# Altium schematic sheet Régulateur USB HT BT.SchDoc("Régulateur USB HT BT")
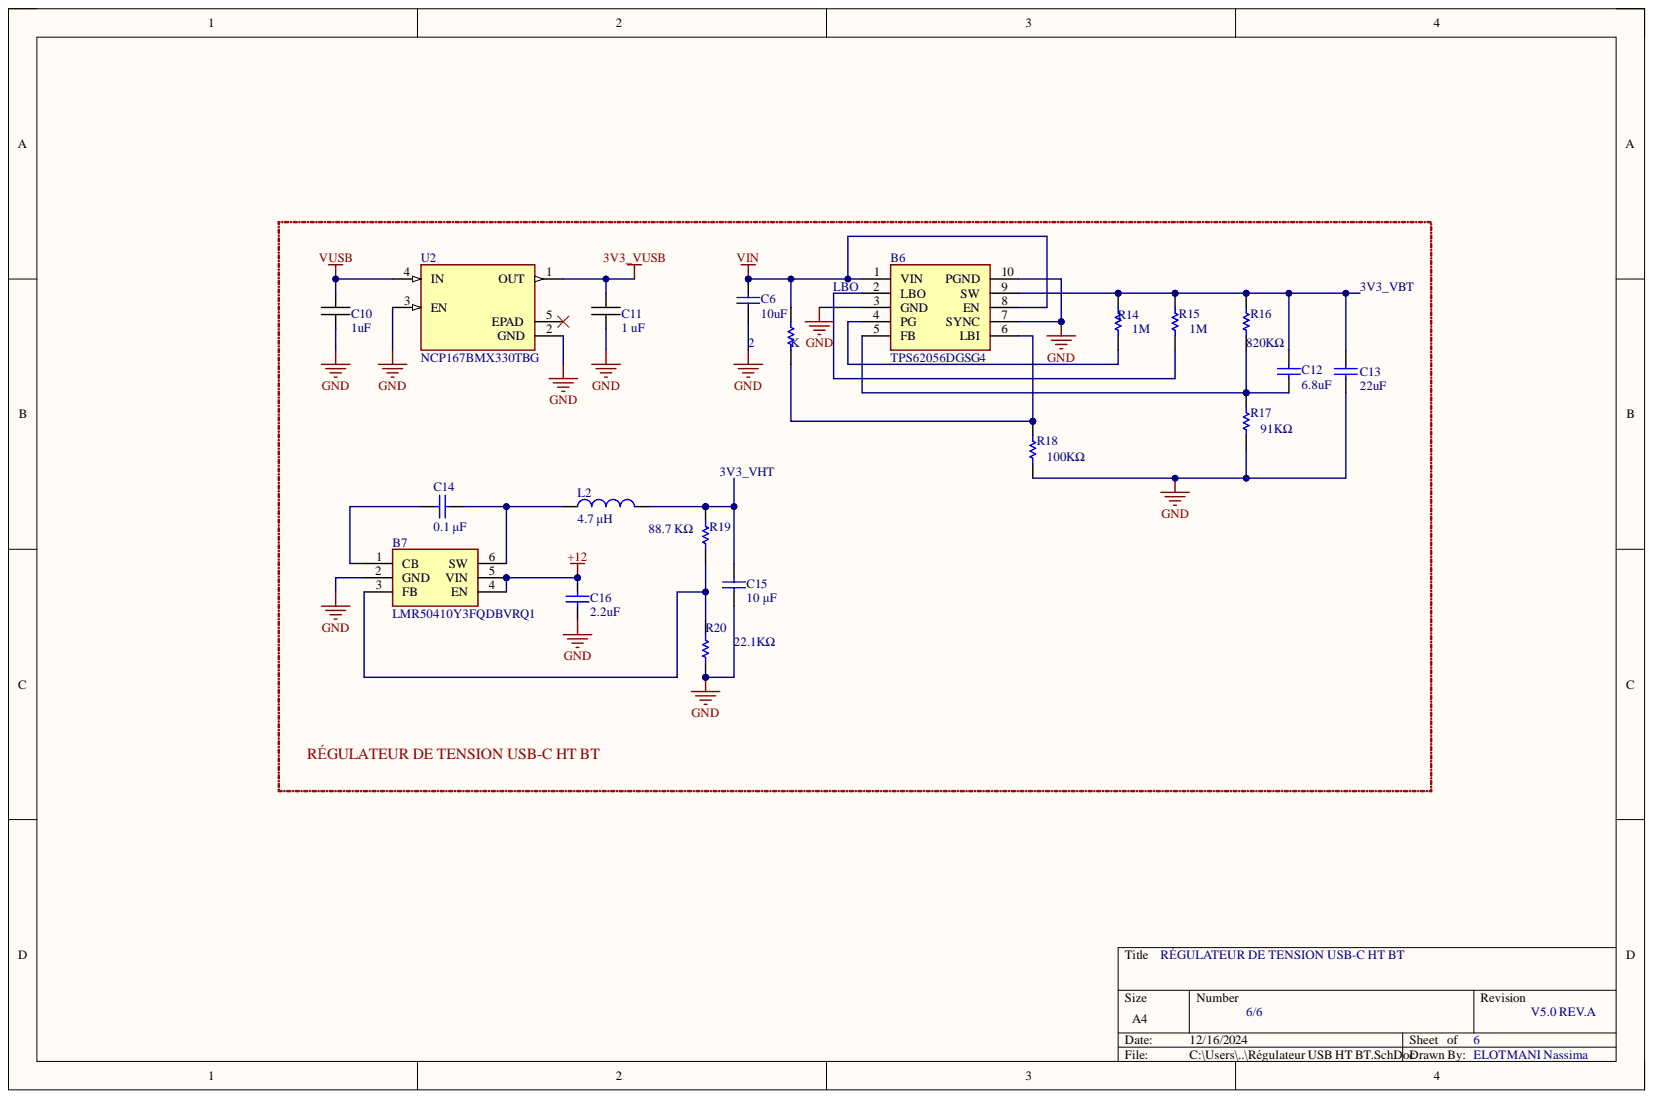
[diagram p0: Régulateur USB HT BT.SchDoc]

## Parts
2  value K  (hidden comment: R6)
B6  TPS62056DGSG4  [SOP50P490X110-10N]
B7  LMR50410Y3FQDBVRQ1  [SOT95P280X145-6N]
C6  value 10uF  (hidden comment: Capacitor)
C10  1uF  [CAPC1005X06N]
C11  1 uF  [CAPC1005X06N]
C12  value 6.8uF  (hidden comment: Capacitor)
C13  value 22uF  (hidden comment: Capacitor)
C14  value 0.1 μF  (hidden comment: Capacitor)
C15  value 10 μF  (hidden comment: Capacitor)
C16  value 2.2uF  (hidden comment: Capacitor)
L2  value 4.7 μH  (hidden comment: Inductor)
R14  value 1M  (hidden comment: Resistor)
R15  value 1M  (hidden comment: Resistor)
R16  value 820KΩ  (hidden comment: Resistor)
R17  value 91KΩ  (hidden comment: Resistor)
R18  value 100KΩ  (hidden comment: Resistor)
R19  value 88.7 KΩ  (hidden comment: Resistor)
R20  value 22.1KΩ  (hidden comment: Resistor)
U2  NCP167BMX330TBG  [NCP167BMX330TBG]

## Nets
3V3_VUSB: C11.2 U2.1(OUT)
+12: B7.4(EN) B7.5(VIN) C16.2
GND: B6.3(GND) B6.7(SYNC) B6.10(PGND) B7.2(GND) C6.1 C10.1 C11.1 C13.1 C15.1 C16.1 R17.1 R18.1 R20.1 U2.2(GND) U2.3(EN)
VIN: 2.2 B6.1(VIN) B6.8(EN) C6.2
VUSB: C10.2 U2.4(IN)
Net2_1: 2.1 B6.6(LBI) R18.2
NetB6_2: B6.2(LBO) R15.1
NetB6_4: B6.4(PG) R14.1
NetB6_5: B6.5(FB) C12.1 R16.1 R17.2
NetB6_9: B6.9(SW) C12.2 C13.2 R14.2 R15.2 R16.2
NetB7_1: B7.1(CB) C14.1
NetB7_3: B7.3(FB) R19.1 R20.2
NetB7_6: B7.6(SW) C14.2 L2.1
NetC15_2: C15.2 L2.2 R19.2

Not connected: U2 5(EPAD)

## Library data of the parts
2 R14-R18 R20 = Resistor: SimulationGenericComponent: True; TC1: 0; Component Kind: Standard; Description: Resistor; Library Name: Simulation Generic Components.IntLib; Simulation: R_Resistor_Default; TC2: 0
B6 = TPS62056DGSG4: TEXAS INSTRUMENTS - TPS62056DGSG4 - Synchronous Buck (Step Down) Switching Regulator, Fixed, 2.7V-10Vin, 3.3V/0.8A out, 850kHz, VSSOP-10; Component Kind: Standard; Library Name: SCHLibrary.SchLib; Manufacturer_Name: Texas Instruments; Manufacturer_Part_Number: TPS62056DGSG4; Mouser Part Number: 595-TPS62056DGSG4
B7 = LMR50410Y3FQDBVRQ1: Régulateurs de tension de commutation Automotive qualified SIMPLE SWITCHER 4-V to 36-V, 1-A synchronous step-down converter 6-SOT-23 -40 to 150; Manufacturer_Name: Texas Instruments; Component Kind: Standard; Library Name: SCHLibrary.SchLib; Manufacturer_Part_Number: LMR50410Y3FQDBVRQ1; Mouser Part Number: 595-R50410Y3FQDBVRQ1
C6 C12-C16 = Capacitor: SimulationGenericComponent: True; Component Kind: Standard; Description: Capacitor; Library Name: Simulation Generic Components.IntLib; Simulation: C_Capacitor_Default
C10 C11 = Capacitor: Component Kind: Standard; Library Name: SCHLibrary.SchLib
L2 = Inductor: SimulationGenericComponent: True; Component Kind: Standard; Description: Inductor; Library Name: Simulation Generic Components.IntLib; Simulation: L_Inductor_Default
R19 = Resistor: SimulationGenericComponent: True; TC1: 0; Value: 88.7 KΩ; Component Kind: Standard; Description: Resistor; Library Name: Simulation Generic Components.IntLib; Simulation: R_Resistor_Default; TC2: 0
U2 = NCP167BMX330TBG: Régulateurs de tension LDO LDO 700 MA ULTRA-LOW NOISE 3.3V; Component Kind: Standard; Library Name: SCHLibrary.SchLib
